# Altium schematic sheet ac_dc_conversion.SchDoc
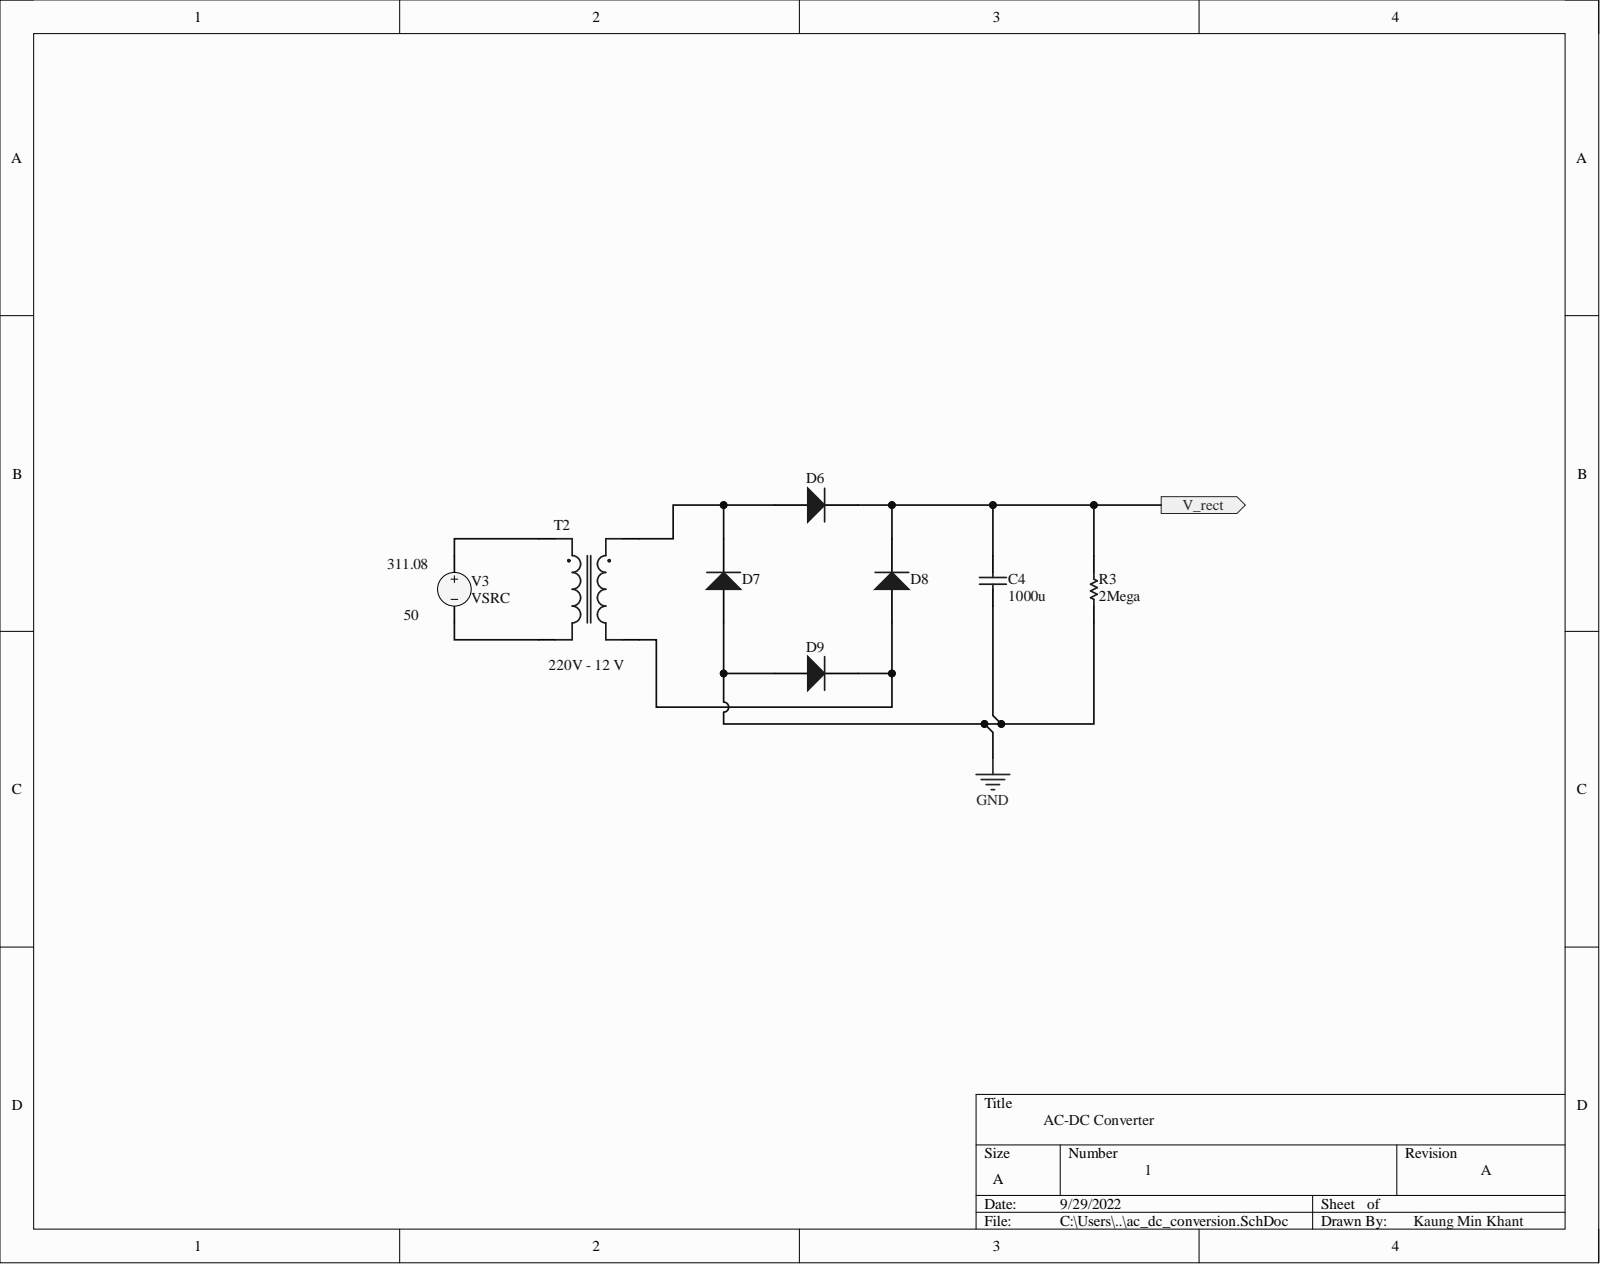
[diagram p0: ac_dc_conversion.SchDoc]

## Parts
C4  value 1000u  (hidden comment: Capacitor)
D6  Diode  (value not drawn)
D7  Diode  (value not drawn)
D8  Diode  (value not drawn)
D9  Diode  (value not drawn)
R3  value 2Mega  (hidden comment: Resistor)
T2  220V - 12 V
V3  VSRC  Amplitude: 311.08

## Ports
V_rect

## Nets
GND: C4.1 D7.1 D9.1 R3.1
V_rect: C4.2 D6.2 D8.2 R3.2 ; port V_rect
NetD6_1: D6.1 D7.2 T2.3
NetD8_1: D8.1 D9.2 T2.4
NetT2_1: T2.1 V3.1
NetT2_2: T2.2 V3.2

## Library data of the parts
C4 = Capacitor: SimulationGenericComponent: True; Component Kind: Standard; Description: Capacitor; Library Name: Simulation Generic Components.IntLib; Simulation: C_Capacitor_Default
D6-D9 = Diode: AF: 1; BV: 0; BV_MAX: 1e99; EG: 1.11; FC: 0.5; IBV: 0.001; IS: 1e-14; MJSW: 0.33; NTUN: 30; SimulationGenericComponent: True; TNOM: 27; XTI: 3; CJO: 0; CJP: 0; Component Kind: Standard; CTA: 0; CTP: 0; Description: Diode; FCS: 0.5; FV_MAX: 1e99; IKF: 0; IKR: 0; ISR: 1e-14; JSW: 0; JTUN: 0; JTUNSW: 0; KEG: 1; KF: 0; LEVEL: 1; Library Name: Simulation Generic Components.IntLib; N: 1; NBV: 1; NR: 1; NS: 1; PHP: 1; RS: 0; Simulation: diode; TCV: 0; TLEV: 0; TLEVC: 0; TM1: 0; TM2: 0; TPB: 0; TPHP: 0; TRS: 0; TRS2: 0; TT: 0; TTT1: 0; TTT2: 0; VJ: 1; XTITUN: 3
R3 = Resistor: SimulationGenericComponent: True; TC1: 0; Component Kind: Standard; Description: Resistor; Library Name: Simulation Generic Components.IntLib; Simulation: R_Resistor_Default; TC2: 0
T2 = Transformer Ideal: Ideal Transformer; GAIN: 1; SimulationGenericComponent: True; Component Kind: Standard; Library Name: Simulation Generic Components.IntLib; Simulation: IDEAL_TRANSFORMER
V3 = VSRC: Voltage Source; AC Magnitude: 1; AC Phase: 0; DC Magnitude: 5; Frequency: 50; Note: PCB Footprint - Not required; Component Kind: Standard; Damping Factor: 0; Delay: 0; LatestRevisionDate: 30-July-2020; LatestRevisionNote: Re-released for DXP Platform.; Library Name: Simulation Generic Components.IntLib; Offset: 0; PackageReference: Not Applicable; Phase: 0; Published: 30-July-2020; Simulation: VSRC; Publisher: Altium Limited
